# Altium schematic sheet Connectors.SchDoc
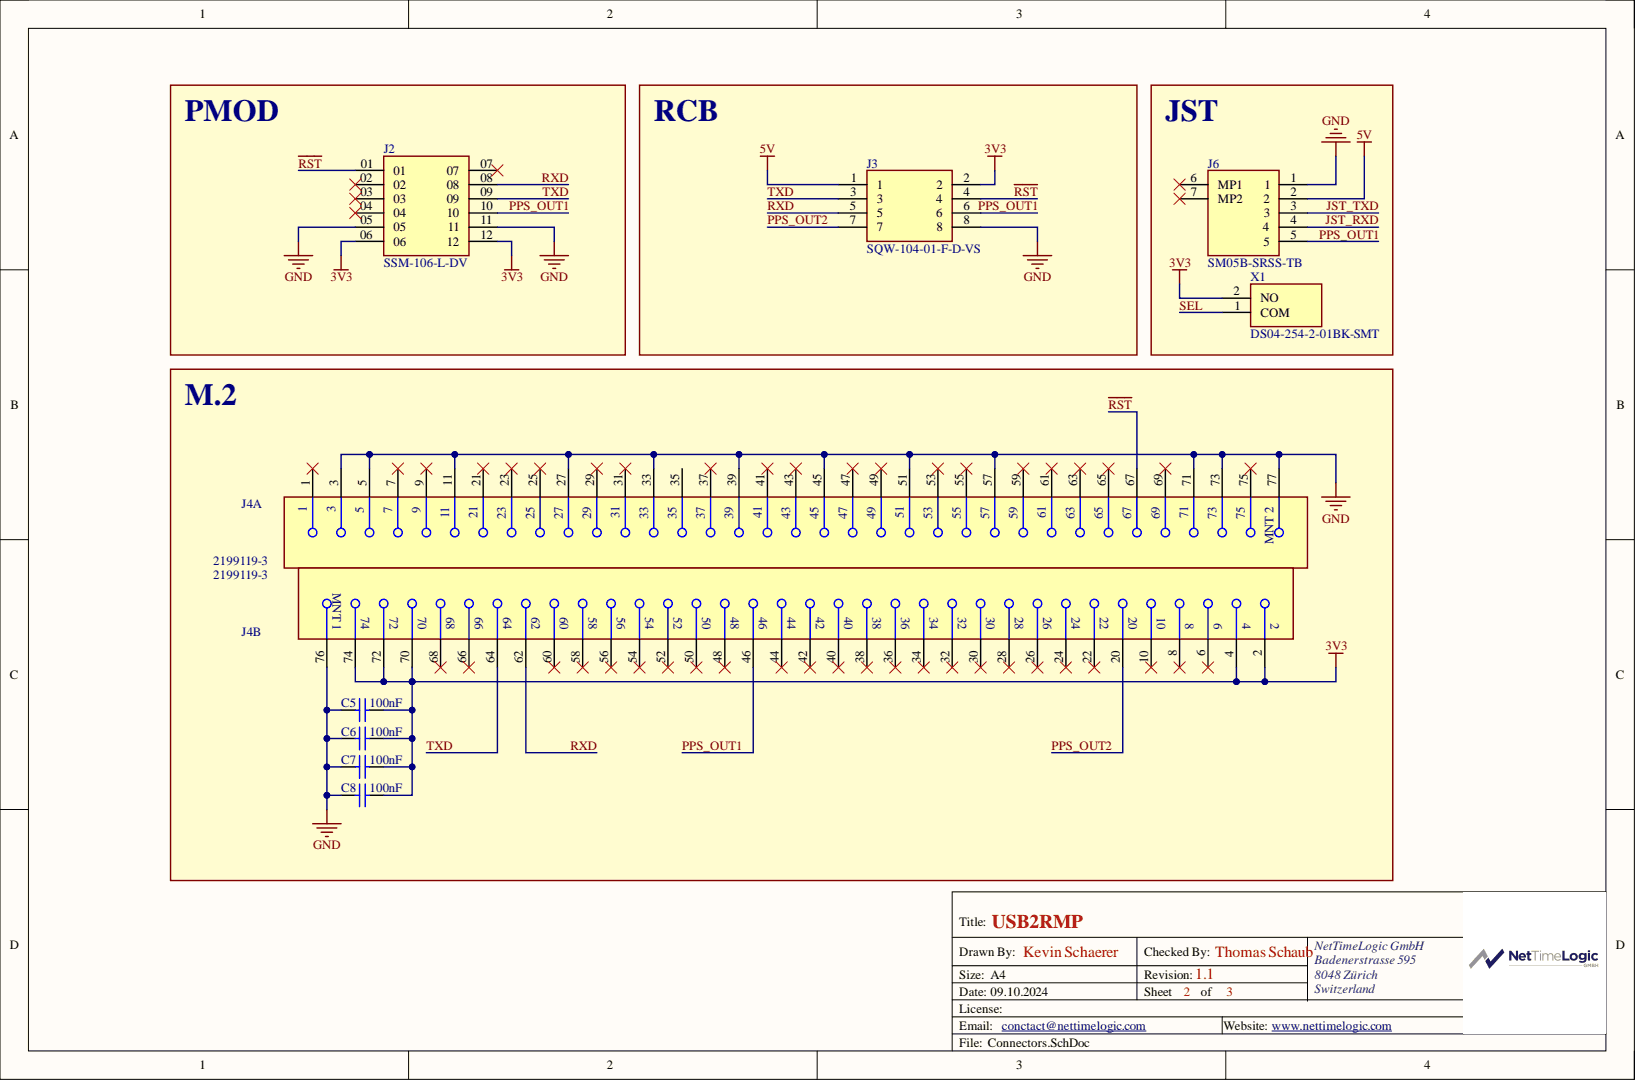
[diagram p0: Connectors.SchDoc]

## Parts
C5  value 100nF  [FP-C0603C-CF-MFG]  (hidden comment: C0603C104K4RACTU)
C6  value 100nF  [FP-C0603C-CF-MFG]  (hidden comment: C0603C104K4RACTU)
C7  value 100nF  [FP-C0603C-CF-MFG]  (hidden comment: C0603C104K4RACTU)
C8  value 100nF  [FP-C0603C-CF-MFG]  (hidden comment: C0603C104K4RACTU)
J2  SSM-106-L-DV  [SAMTEC_SSM-106-L-DV]
J3  SQW-104-01-F-D-VS  [SAMTEC-SQW-104-01-X-D-VS]
J4  2199119-3  [FP-2199119-3-MFG]  (parts A,B)
J6  SM05B-SRSS-TB  [SM05BSRSSTBLFSN]
X1  DS04-254-2-01BK-SMT  [DS04254201BKSMTTR]

## Nets
3V3: C5.2 C6.2 C7.2 C8.2 J2.06 J2.12 J3.2 J4.2 J4.4 J4.70 J4.72 J4.74 X1.2
5V: J3.1 J6.2
GND: C5.1 C6.1 C7.1 C8.1 J2.05 J2.11 J3.8 J4.3 J4.5 J4.11 J4.27 J4.33 J4.39 J4.45 J4.51 J4.57 J4.71 J4.73 J4.76 J4.77 J6.1
~{RST}: J2.01 J3.4 J4.67
JST_RXD: J6.4
JST_TXD: J6.3
PPS_OUT1: J2.10 J3.6 J4.46 J6.5
PPS_OUT2: J3.7 J4.20
RXD: J2.08 J3.5 J4.62
SEL: X1.1
TXD: J2.09 J3.3 J4.64

Not connected: J2 02 03 04 07; J4 1 6 7 8 9 10 21 22 23 24 25 26 28 29 30 31 32 34 35 36 37 38 40 41 42 43 44 47 48 49 50 52 53 54 55 56 58 59 60 61 63 65 66 68 69 75; J6 6(MP1) 7(MP2)

## Library data of the parts
C5-C8 = CMP-00009-15: Cap Ceramic 0.1uF 16V X7R 10% SMD 0603 125°C Paper T/R; Dissipation Factor: 3.5%; Case Code (Imperial): 0603; Case Code (Metric): 1608; Case/Package: 0603; Component Kind: Standard; Construction: Flat; Contact Plating: Tin,Matte; Depth: 0.8mm; Dielectric: X7R; Dielectric Material: Ceramic; Height: 0.8mm; Lead Pitch: 0.7mm; Length: 1.6mm; Max Operating Temperature: 125°C; Min Operating Temperature: -55°C; Mounting Technology: Surface Mount,PCB; Package Quantity: 4000; Packaging: Tape and Reel; Pins: 2; Radiation Hardening: No; Reach SVHC Compliant: Yes; Series: SMD Comm X7R; Termination: SMD/SMT; Thickness: 0.034inch; Tolerance: 10%; Voltage: 16V; Voltage Rating: 16V; Voltage Rating (DC): 16V; Weight: 7.1E-05oz; Width: 0.8mm; Library Name: NetTimeLogic GmbH
J2 = CMP-020-000000-6: Header Surface Mount (2.54mm) 2x6; MF: Samtec; Availability: Unavailable; Component Kind: Standard; Component Type: Standard; Design Item ID: SSM-106-L-DV; MP: SSM-106-L-DV; Package: None; Pin Count: 12; Price: None; Source: SSM-106-L-DV.SchLib; Library Name: NetTimeLogic GmbH
J3 = CMP-199-000000-4: Headers & Wire Housings Cost Effective Rugged PCB Sockets, 2.00mm pitch; Availability: Unavailable; Component Kind: Standard; Component Type: Standard; Design Item ID: SAMTEC-SQW-104-01-X-D-VS; MP: SAMTEC-SQW-104-01-X-D-VS; Package: None; Pin Count: 8; Price: None; Source: SAMTEC-SQW-104-01-X-D-VS.SchLib; Library Name: NetTimeLogic GmbH
J4 = CMP-002-00009-1: Conn Card Edge SKT 67 POS 0.5mm Solder RA SMD Embossed T/R; Component Kind: Standard; Library Name: NetTimeLogic GmbH
J6 = CMP-244-000000-2: Board Connector, 5 Contact(s), 1 Row(s), Male, Right Angle, Surface Mount Terminal; Manufacturer_Name: JST (JAPAN SOLDERLESS TERMINALS); Manufacturer_Part_Number: SM05B-SRSS-TB(LF)(SN); Connector Type: Header; Contact Resistance: 40mR; Current Rating: 1A; Geometry.Height: 2.95mm; Insulation Resistance: 100MR; Max Voltage Rating (AC): 50V; Number of Contacts: 5; Pitch: 0.039inch; Color: Natural; Component Kind: Standard; Contact Finish - Mating: TIN; Contact Material: Copper Alloy; Contact Plating: Tin,Lead; Contact Shape: Square; Contact Type: Male Pin; Datasheet Link: http://www.jst-mfg.com/product/pdf/eng/eSH.pdf; Depth: 4.95mm; Design Item ID: SM05B-SRSS-TB_LF__SN_; Fastening Type: Pull; Flammability Rating: UL94 V-0; Gender: Male; Housing Color: Natural; Housing Material: Nylon; Insulation Color: Natural; Insulation Material: Nylon; Lead Free: Contains Lead; Lead Pitch: 1mm; Length: 7mm; Material: Polyamide; Max Current Rating: 1A; Max Operating Temperature: 85°C; Max Voltage Rating (DC): 50V; Min Operating Temperature: -25°C; Mounting Technology: Surface Mount; Number of Positions: 5; Number of Rows: 1; Orientation: Right Angle; Package Quantity: 1; Packaging: Cut Tape; Reach SVHC Compliant: No; Series: SR; Source: SM05B-SRSS-TB_LF__SN_.SchLib; Termination: Solder; Voltage Rating: 50V; Voltage Rating (AC): 50V; Library Name: NetTimeLogic GmbH
X1 = CMP-247-000000-2: Switch DIP OFF ON SPST 1 Flat Slide 0.025A 24VDC Gull Wing 2.54mm SMD Tube; Manufacturer_Name: Same Sky; Manufacturer_Part_Number: DS04-254-2-01BK-SMT-TR; Actuator Type: Slide (Standard); Body Material: PBT,Polybutylene; Electrical Life: 2000Cycles; Geometry.Height: 4.3mm; Number of Positions: 1; Switching Current: 25mA; Switching Voltage: 24V; Washable: No; Component Kind: Standard; Contact Material: Copper Alloy; Contact Plating: Gold; Datasheet Link: https://www.cuidevices.com/product/resource/supplyframepdf/ds04-254-smt.pdf; Design Item ID: DS04-254-2-01BK-SMT-TR; Height: 3.66mm; Length: 3.54mm; Max Operating Temperature: 85°C; Min Operating Temperature: -40°C; Mounting Technology: Surface Mount; Mouser Part Number: 490-DS04254201BK-STR; Mouser Price/Stock: https://www.mouser.co.uk/ProductDetail/CUI-Devices/DS04-254-2-01BK-SMT-TR?qs=wnTfsH77Xs4xXRFXKF0ssg%3D%3D; Pitch: 2.54mm; Series: DS04-254-SMT; Source: DS04-254-2-01BK-SMT-TR.SchLib; Termination: Gull Wing; Throw Configuration: SPST; Voltage Rating (DC): 24V; Width: 6.2mm; Library Name: NetTimeLogic GmbH
